# Altium schematic sheet ZYNQ_USB_PHY.SchDoc(ZYNQ_USB_PHY)
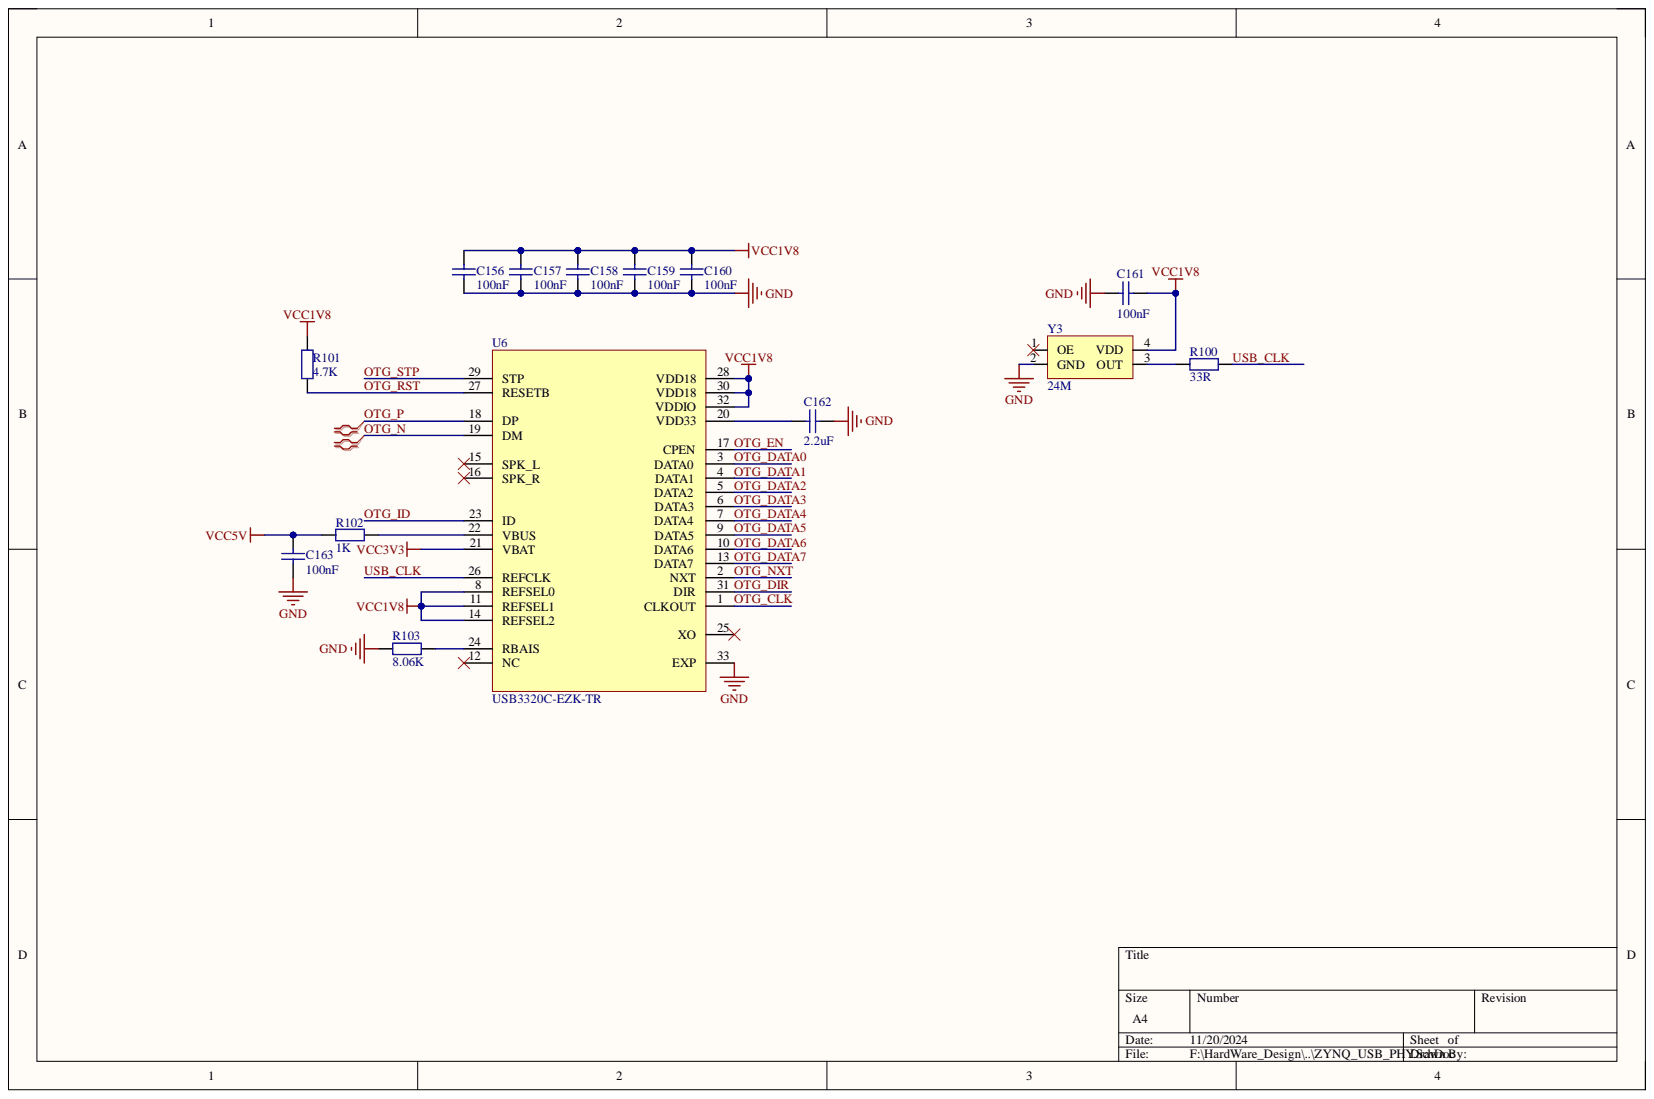
[diagram p0: ZYNQ_USB_PHY.SchDoc]

## Parts
C156  100nF  [C 0402_L]
C157  100nF  [C 0402_L]
C158  100nF  [C 0402_L]
C159  100nF  [C 0402_L]
C160  100nF  [C 0402_L]
C161  100nF  [C 0402_L]
C162  2.2uF  [C 0402_L]
C163  100nF  [C 0402_L]
R100  33R  [R 0402_L]
R101  4.7K  [R 0402_L]
R102  1K  [R 0402_L]
R103  8.06K  [R 0402_L]
U6  USB3320C-EZK-TR  [QFN50P500X500X100-33N-D]
Y3  24M  [OSC 3225-4P]

## Nets
GND: C156.1 C157.1 C158.1 C159.1 C160.1 C161.2 C162.2 C163.1 R103.1 U6.33(EXP) Y3.2(GND)
VCC1V8: C156.2 C157.2 C158.2 C159.2 C160.2 C161.1 R101.2 U6.8(REFSEL0) U6.11(REFSEL1) U6.14(REFSEL2) U6.28(VDD18) U6.30(VDD18) U6.32(VDDIO) Y3.4(VDD)
VCC3V3: U6.21(VBAT)
VCC5V: C163.2 R102.1
OTG_CLK: U6.1(CLKOUT)
OTG_DATA0: U6.3(DATA0)
OTG_DATA1: U6.4(DATA1)
OTG_DATA2: U6.5(DATA2)
OTG_DATA3: U6.6(DATA3)
OTG_DATA4: U6.7(DATA4)
OTG_DATA5: U6.9(DATA5)
OTG_DATA6: U6.10(DATA6)
OTG_DATA7: U6.13(DATA7)
OTG_DIR: U6.31(DIR)
OTG_EN: U6.17(CPEN)
OTG_ID: U6.23(ID)
OTG_N: U6.19(DM)
OTG_NXT: U6.2(NXT)
OTG_P: U6.18(DP)
OTG_RST: R101.1 U6.27(RESETB)
OTG_STP: U6.29(STP)
USB_CLK: R100.2 U6.26(REFCLK)
NetC162_1: C162.1 U6.20(VDD33)
NetR100_1: R100.1 Y3.3(OUT)
NetR102_2: R102.2 U6.22(VBUS)
NetR103_2: R103.2 U6.24(RBAIS)

Not connected: U6 12(NC) 15(SPK_L) 16(SPK_R) 25(XO); Y3 1(OE)

## Library data of the parts
C156-C163 = Cap: 贴片电容; Component Kind: Standard; Library Name: A_Schlib.SchLib
  C156-C161 C163: value: 100nF
  C162: value: 2.2uF
R100-R103 = Res: 通用; Component Kind: Standard; Library Name: A_Schlib.SchLib
  R100: value: 33R
  R101: value: 4.7K
  R102: value: 1K
  R103: value: 8.06K
U6 = USB3320C-EZK-TR: USB-PHY芯片; Component Kind: Standard; Library Name: A_Schlib.SchLib
Y3 = XATLS-4P: 4脚贴片有源晶振; Component Kind: Standard; Library Name: A_Schlib.SchLib; Value: 24M; 封装库来自淘宝卖家 ID：黄晶宝石: https://shop463601444.taobao.com
